# Altium schematic sheet Power.SchDoc(Power)
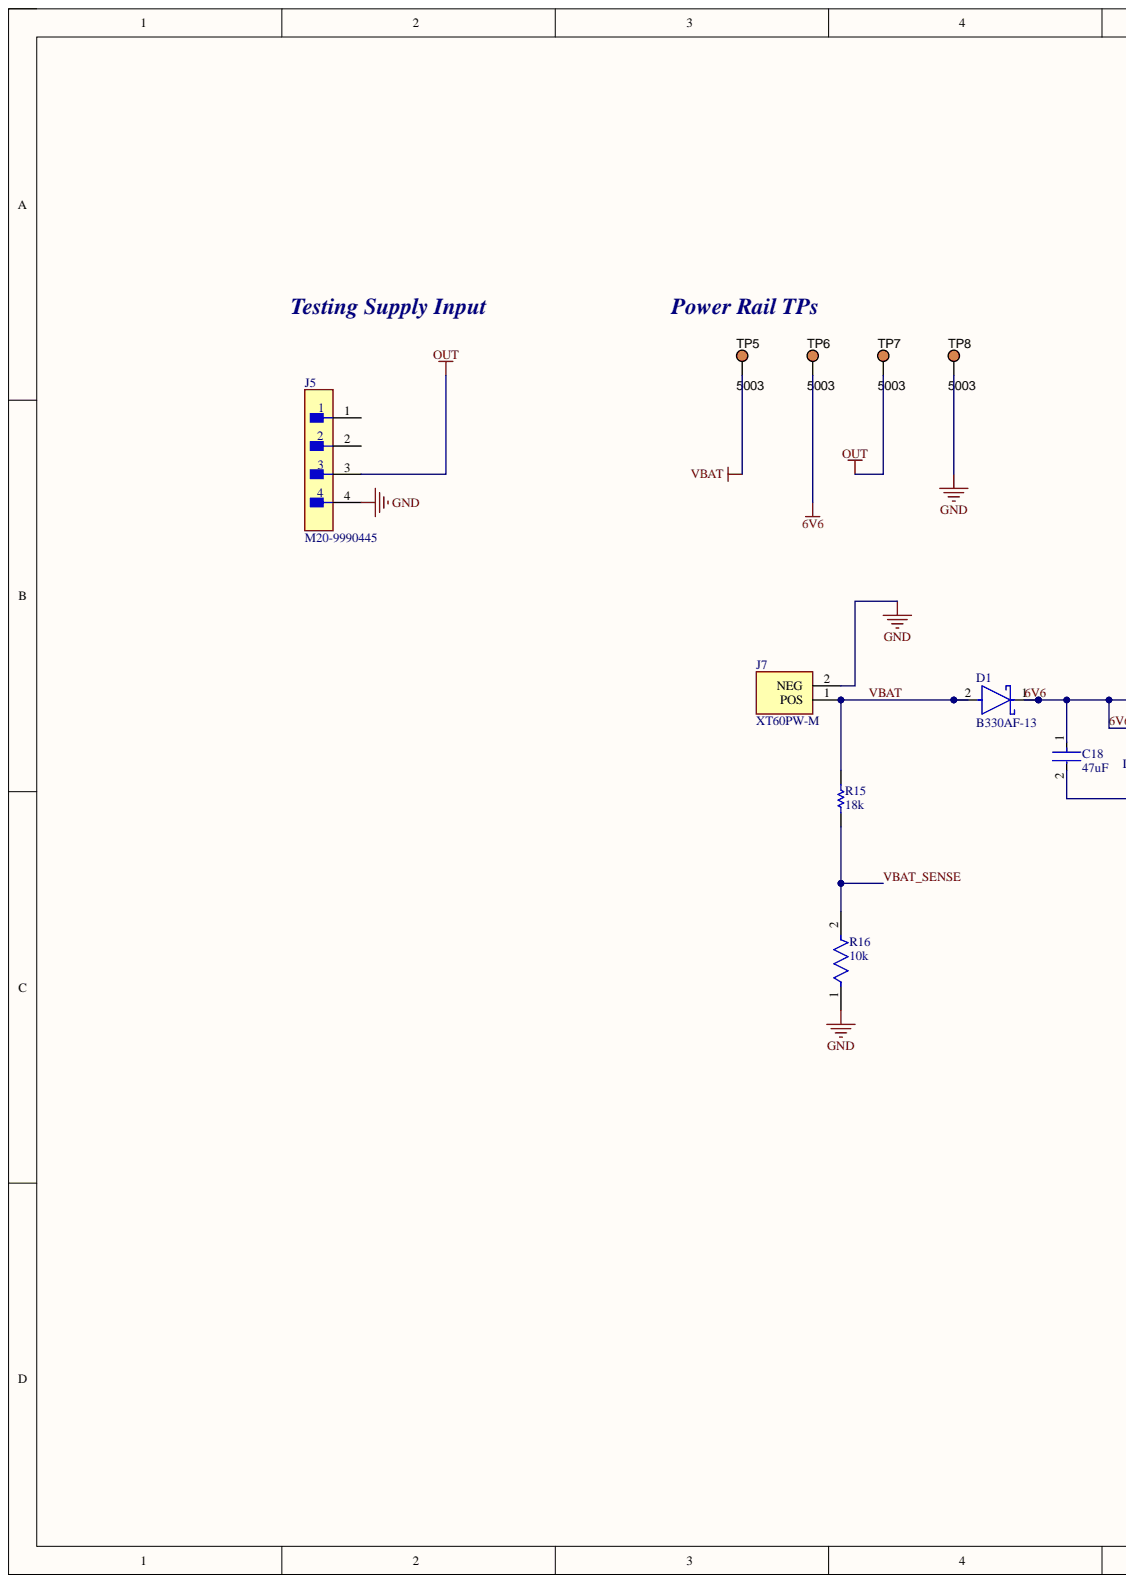
[diagram p0: Power.SchDoc, left]
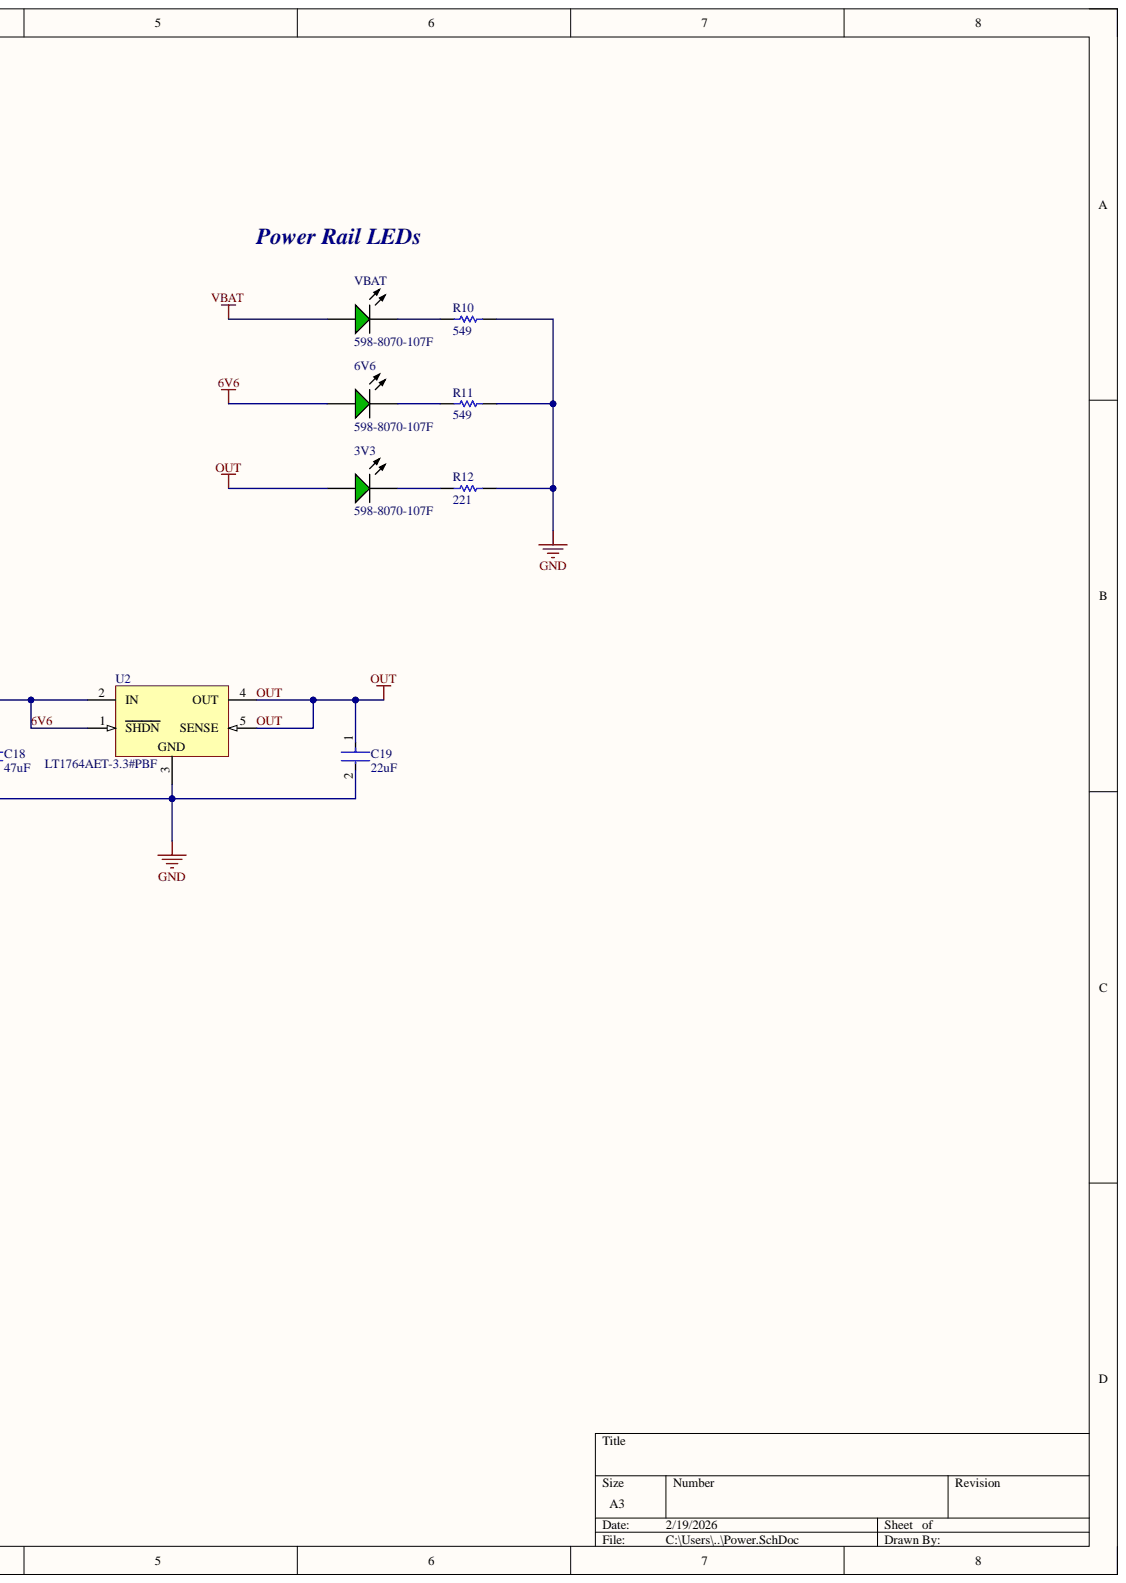
[diagram p1: Power.SchDoc, right]

## Parts
3V3  598-8070-107F  [DIAL-0603-2_V]
6V6  598-8070-107F  [DIAL-0603-2_V]
C18  47uF  [FP-GRT31C-0_2-IPC_A]
C19  22uF  [FP-GRM32E-0_3-0_2-IPC_B]
D1  B330AF-13  [FP-SMAF-MFG]
J5  M20-9990445  [FP-M20-9990445-MFG]
J7  XT60PW-M  [AMASS_XT60PW-M]
R10  549  [RESC1608X55X25NL10T15]
R11  549  [RESC1608X55X25NL10T15]
R12  221  [RESC1608X55X25NL10T15]
R15  18k  [RESC1608X55X25LL10T15]
R16  10k  [FP-RC0603-0_55-IPC_C]
TP5  5003  [KSTN-5003]
TP6  5003  [KSTN-5003]
TP7  5003  [KSTN-5003]
TP8  5003  [KSTN-5003]
U2  LT1764AET-3.3#PBF  [LT-T-5-S]
VBAT  598-8070-107F  [DIAL-0603-2_V]

## Nets
GND: C18.2 C19.2 J5.4 J7.2 R10.2 R11.2 R12.2 R16.1 TP8.1 U2.3
6V6: 6V6.2 C18.1 D1.1 TP6.1 U2.1(SHDN) U2.2(IN)
OUT: 3V3.2 C19.1 J5.3 TP7.1 U2.4(OUT) U2.5(SENSE)
VBAT: D1.2 J7.1 R15.2 TP5.1 VBAT.2
VBAT_SENSE: R15.1 R16.2
Net3V3_1: 3V3.1 R12.1
Net6V6_1: 6V6.1 R11.1
NetR10_1: R10.1 VBAT.1

Not connected: J5 1 2

## Library data of the parts
3V3 6V6 VBAT = CMP-2000-05654-1: Single Color LED, 2 V, -30 to 85 degC, 2-Pin SMD (0603), RoHS, Tape and Reel; Manufacturer: Dialight; Chip Material: GaP; DELETED: Compliant; Dominant Wave Length: 568nm; Emitting Color: YellowGreen; Forward Current: 25mA; Forward Voltage: 2.4V; High Brightness: No; Lum Intensity: 20mcd; Main Category: ChipLED; Number of  Elements: 1; Power Dissipation: 60W; Product Depth (mm): 0.8mm; Product Height (mm): 0.7mm; Product Length (mm): 1.6mm; Reverse Voltage: 5V; Shape Type: Rectangular; Test Current (It): 20mA; Viewing Angle: 140deg; Component Kind: Standard; Datasheet URL: https://datasheet.ciiva.com/4688/598-selectorguide-18902-4688880.pdf; Datasheet Version: Rev. 2, 10/2008; Lens Dimensions: 1.1x0.8x0.4mm; Library Name: Altium Content Vault; Luminous Intensity: 20mcd; Manufacturer URL: http://www.dialight.com/; Mounting: SurfaceMount; Mounting Technology: SurfaceMount; Operating Temp Range: -40Cto100C; Operating Temperature Classification: Industrial; Package Description: 2-Pin Surface Mount Device, Body 1.6 x 0.8 mm; Package Reference: 0603; Package Type: SMD; Packaging: TapeandReel; Pin Count: 2; Rad Hardened: No; Type: Uni-Color
C18 = CMP-06035-027336-1: Multi-Layer Ceramic Capacitor 47uF 10V X5R ±10% 1206 Emboss T/R; Component Kind: Standard; Library Name: Altium Content Vault
C19 = CMP-06035-011048-1: Chip Multilayer Ceramic Capacitors for General Purpose, 1210, 22uF, X7R, 15%, 10%, 16V; Capacitance: 22uF; Case Code (Imperial): 1210; Case Code (Metric): 3225; Case/Package: 1210; Component Kind: Standard; Depth: 1.6mm; Dielectric: X7R; Height: 2.5mm; Lead Free: Lead Free; Length: 3.2mm; Library Name: Altium Content Vault; Material: Ceramic; Max Operating Temperature: 125°C; Min Operating Temperature: -55°C; Mount: Surface Mount; Package Quantity: 1; Packaging: Tape and Reel; Radiation Hardening: No; REACH SVHC: No SVHC; Resistor Type: Ceramic; Termination: SMD/SMT; Thickness: 0.106inch; Tolerance: 10%; Voltage: 16V; Voltage Rating: 16V; Voltage Rating (DC): 16V; Weight: 0.00388oz; Width: 2.5mm
D1 = CMP-12790-000091-1: DIODE SCHOTTKY 30V 3A SMAF; Average Rectified Current: 3A; Component Kind: Standard; Library Name: Altium Content Vault; Max Reverse Voltage (DC): 30V; Mount: Surface Mount; Packaging: Tape and Reel; RoHS Compliant: Yes
J5 = CMP-00671-05399696-1: M20-9990445 Conn Unshrouded Header HDR 4 POS 2.54mm Solder ST Thru-Hole Bulk/Loose; Connector Type: Male Pin; Contact Resistance: 25mΩ; Current Rating: 3A; Gender: Male; Insulation Resistance: 10GΩ; Number of Contacts: 4; Voltage Rating (AC): 750V; Case/Package: SIL; Color: Black; Component Kind: Standard; Contact Material: Brass, Bronze, Gold, Nickel; Contact Mating Length: 6.096mm; Contact Plating: Gold; Depth: 2.54mm; Height: 2.54mm; Housing Color: Black; Housing Material: Nylon; Lead Pitch: 2.54mm; Length: 10.16mm; Library Name: Altium Content Vault; Max Current Rating: 3A; Max Operating Temperature: 105°C; Min Operating Temperature: -40°C; Mount: Through Hole; NexarPartId: 5399696; Number of Pins: 4; Number of Positions: 4; Number of Rows: 1; Orientation: Straight; Pitch: 2.54mm; Radiation Hardening: No; REACH SVHC: No SVHC; RoHS: Compliant; Termination: Solder; Voltage Rating (DC): 750V; Weight: 45.359237g; Width: 12.7mm
J7 = XT60PW-M: Socket, DC supply, male, PIN: 2; MF: AMASS; Availability: Not in stock; Check_prices: https://www.snapeda.com/parts/XT60PW-M/AMASS/view-part/?ref=eda; Component Kind: Standard; Library Name: XT60PW-M.IntLib; MP: XT60PW-M; Package: Package; Price: None; SnapEDA_Link: https://www.snapeda.com/parts/XT60PW-M/AMASS/view-part/?ref=snap
R10 R11 = CMP-2000-04082-1: Manufacturer: Yageo; Part Number: RC0603FR-07549RL; Component Kind: Standard; Library Name: Altium Content Vault
R12 = CMP-2000-03958-1: Manufacturer: Yageo; Part Number: RC0603FR-07221RL; Component Kind: Standard; Library Name: Altium Content Vault
R15 = CMP-2000-06930-1: Chip Resistor, 18 KOhm, +/- 1%, 0.1 W, -55 to 155 degC, 0603 (1608 Metric), RoHS, Tape and Reel; Brand: Yageo; Power Rating: 100mW; Resistance: 18kOhms; Temperature Coefficient: 100PPM/C; Unit Weight: 0.000071oz; Voltage Rating: 75V; Case Code - in: 0603; Case Code - mm: 1608; Component Kind: Standard; Datasheet URL: http://www.yageo.com/documents/recent/PYu-RC_Group_51_RoHS_L_7.pdf; Datasheet Version: Rev. 7, 05/2017; Height: 0.45mm; Length: 1.6mm; Library Name: Altium Content Vault; Manufacturer: Yageo; Manufacturer URL: http://www.yageo.com/; Mounting Technology: SurfaceMount; Operating Temperature Range: -55Cto+155C; Package / Case: 0603(1608metric); Package Description: 2-Pin Surface Mount Device, Body 1.6 x 0.8 mm; Package Reference: 0603; Packaging: Reel; Product: ThickFilmResistorsGeneralPurpose; Product Category: ThickFilmResistors; RoHS: True; Series: RC; Termination Style: SMD/SMT; Tolerance: 1%; Type: GeneralPurposeChipResistorSurfaceMount; Width: 0.8mm
R16 = CMP-2100-03664-2: RES 10K OHM 1% 1/10W 0603; Max Power Dissipation: 100mW; Resistance: 10kR; Temperature Coefficient: 100ppm/°C; Voltage Rating: 75V; Voltage Rating (DC): 50V; Case Code (Imperial): 0603; Case Code (Metric): 1608; Case/Package: 0603; Component Kind: Standard; Construction: Rectangular; Depth: 0.8mm; Features: Moisture Resistant; Height: 0.45mm; Lead Free: Lead Free; Lead Pitch: 0.3mm; Length: 1.6mm; Library Name: Altium Content Vault; Max Operating Temperature: 155°C; Military Standard: Not; Min Operating Temperature: -55°C; Number of Pins: 2; Number of Terminations: 2; Packaging: Tape and Reel; Power Rating: 100mW; Resistance Tolerance: 1%; Resistor Type: Thick Film; RoHS Compliant: Yes; Series: RC; Size Code: 0603; Termination: SMD/SMT; Tolerance: 1%; Weight: 7.1E-05oz; Width: 0.8mm
TP5-TP8 = CMP-002-00037-2: Test Point, Orange, Height 4.6 mm, Tail Length 3 mm, 1-Pin THD, RoHS; Manufacturer: Keystone; Case/Package: 5003; Colour: Orange; Component Kind: Standard; Connector Type: TestPoint; Contact Material: Bronze; Contact Plating: Tin; Datasheet URL: http://keyelco.com/userAssets/file/M65p56.pdf; Datasheet Version: Jun-15; Diameter: 2.54mm; Manufacturer URL: http://www.keyelco.com/; Mounting Technology: TH; Package Description: 1-Pin Through Hole Device, Dia 2.54 mm; Package Quantity: 10; Package Reference: 5003; Packaging: Bulk; Pins: 1; RoHS Compliant: True; Library Name: Thomas Tran
U2 = CMP-0476-01973-1: 3 A, Fast Transient Response, Low Noise, LDO Regulator, 2.7 to 20 V Vin, 3.3 V Vout, 5-pin FM (T-5), -40 to 125 degC, Pb-Free; Manufacturer: Linear Technology; Discrete Pass Element: no; Dropout Voltage(V): 0.34; Iout(mA): 3000; Isupply(mA): 1; Noise(uV): 40; Output Voltage: 3.3 V; PSRR(dB): 63; Shutdown Current(uA): 0.01; Vin Max(V): 20; Vin Min(V): 2.7; Component Kind: Standard; ComponentLink1Description: Manufacturer URL; ComponentLink1URL: http://www.linear.com/; ComponentLink2Description: Datasheet; ComponentLink2URL: http://cds.linear.com/docs/en/datasheet/1764afb.pdf; ComponentLink3Description: Package Specification; ComponentLink3URL: http://cds.linear.com/docs/en/packaging/TO-220_5_05-08-1421.pdf; DatasheetVersion: Rev. B; Library Name: Altium Content Vault; Mounting Technology: Through Hole; Number of Outputs: 1; PackageDescription: 5-Pin Plastic TO-220 (Standard), Body 10.224 x 8.89 mm, Pitch 1.7 mm; PackageReference: T-5; PackageVersion: Aug-10; PartNumber: LT1764AET-3.3#PBF; RoHS: TRUE
